# Altium schematic sheet COMPONENT BLOCKS 2.SchDoc
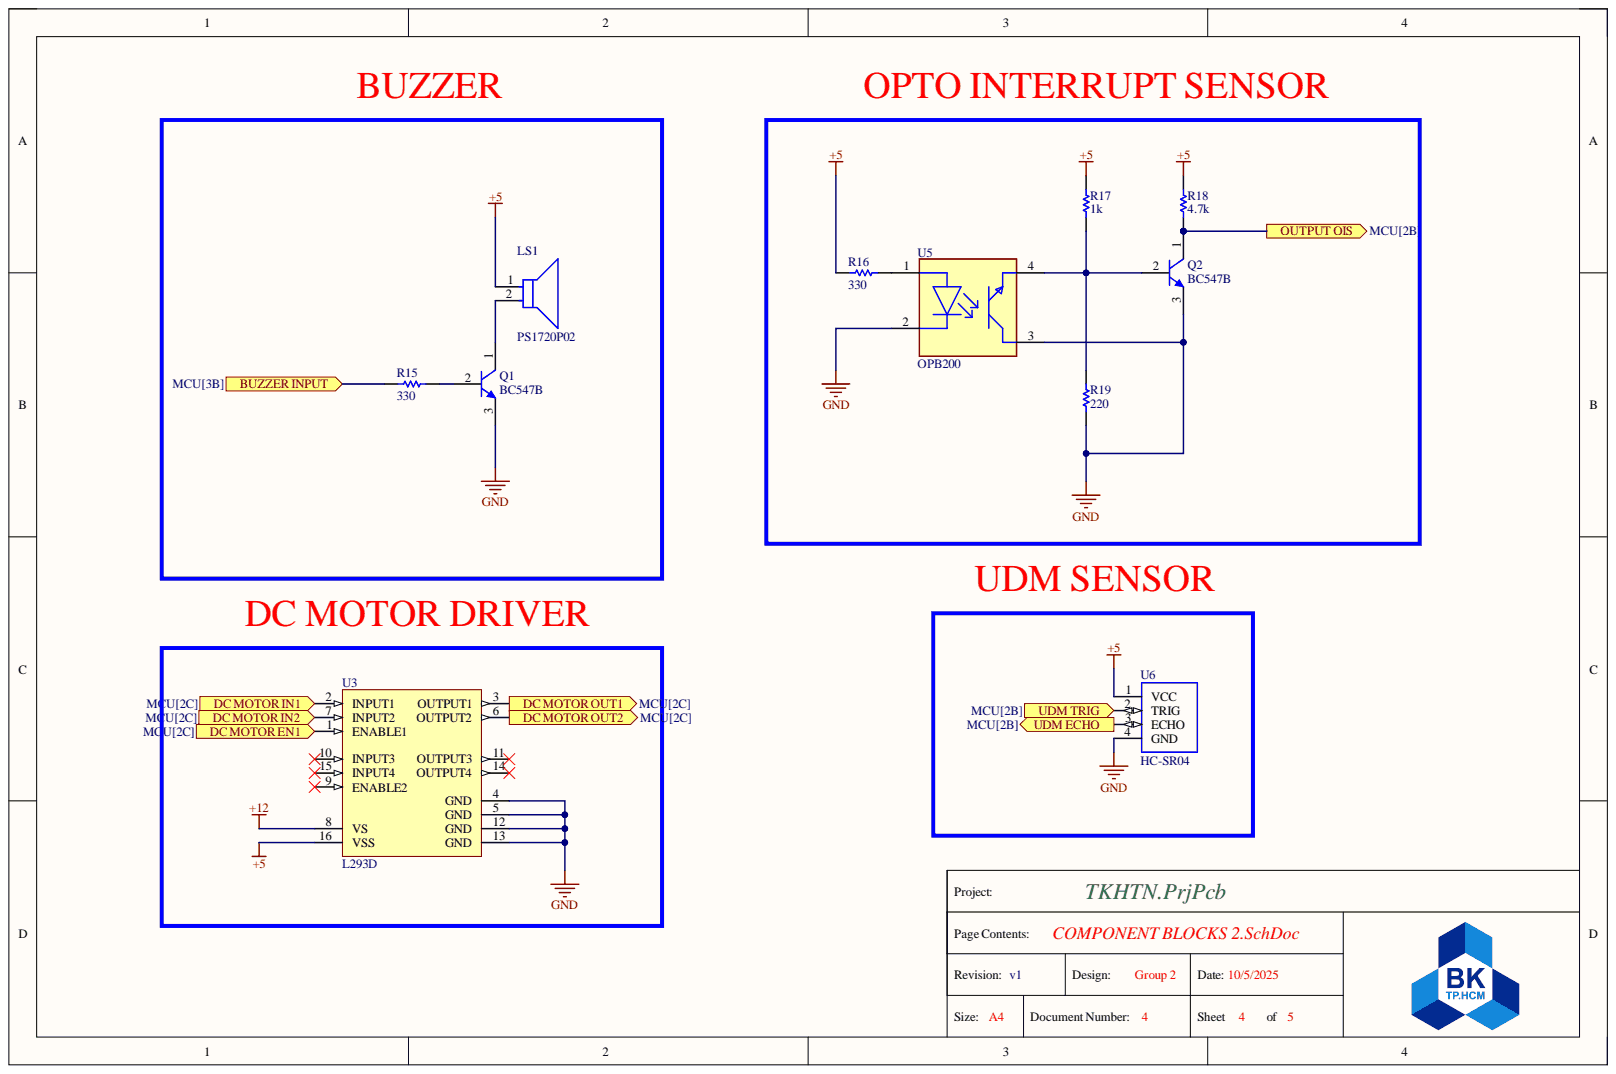
[diagram p0: COMPONENT BLOCKS 2.SchDoc]

## Parts
LS1  PS1720P02  [FP-PS1720P02-MFG]
Q1  BC547B  [ONSC-TO-92-3-29-11]
Q2  BC547B  [ONSC-TO-92-3-29-11]
R15  value 330  (hidden comment: Resistor)
R16  value 330  (hidden comment: Resistor)
R17  value 1k  (hidden comment: Resistor)
R18  value 4.7k  (hidden comment: Resistor)
R19  value 220  (hidden comment: Resistor)
U3  L293D  [STM-L293D-16]
U5  OPB200  [FP-OPB200-MFG]
U6  HC-SR04  [XCVR_HC-SR04]

## Ports
BUZZER INPUT, DC MOTOR EN1, DC MOTOR IN1, DC MOTOR IN2, DC MOTOR OUT1, DC MOTOR OUT2, OUTPUT OIS, UDM ECHO, UDM TRIG

## Nets
+5: LS1.1 R16.1 R17.2 R18.2 U3.16(VSS) U6.1(VCC)
+12: U3.8(VS)
GND: Q1.3 Q2.3 R19.1 U3.4(GND) U3.5(GND) U3.12(GND) U3.13(GND) U5.2 U5.3 U6.4(GND)
BUZZER INPUT: R15.1 ; port BUZZER INPUT
DC MOTOR EN1: U3.1(ENABLE1) ; port DC MOTOR EN1
DC MOTOR IN1: U3.2(INPUT1) ; port DC MOTOR IN1
DC MOTOR IN2: U3.7(INPUT2) ; port DC MOTOR IN2
DC MOTOR OUT1: U3.3(OUTPUT1) ; port DC MOTOR OUT1
DC MOTOR OUT2: U3.6(OUTPUT2) ; port DC MOTOR OUT2
OUTPUT OIS: Q2.1 R18.1 ; port OUTPUT OIS
UDM ECHO: U6.3(ECHO) ; port UDM ECHO
UDM TRIG: U6.2(TRIG) ; port UDM TRIG
NetLS1_2: LS1.2 Q1.1
NetQ1_2: Q1.2 R15.2
NetQ2_2: Q2.2 R17.1 R19.2 U5.4
NetR16_2: R16.2 U5.1

Not connected: U3 9(ENABLE2) 10(INPUT3) 11(OUTPUT3) 14(OUTPUT4) 15(INPUT4)

## Library data of the parts
LS1 = CMP-08413-000001-1: AUDIO PIEZO TRANSDUCER 30V TH; Manufacturer: TDK Corporation; Frequency: 2kHz; Standoff Height: 0.5mm; Automotive: No; Category: Speak; Component Kind: Standard; ComponentLink2Description: Datasheet; ComponentLink2URL: https://product.tdk.com/info/en/catalog/datasheets/piezoelectronic_buzzer_ps_en.pdf; Device Class L1: Electromechanical; Device Class L2: Audio Products; Device Class L3: Buzzers; Digikey Description: AUDIO PIEZO TRANSDUCER 30V TH; Height: 8.3mm; Lead Free: Yes; Library Name: piezo buzzer.IntLib; Manufacturer Part Number: PS1720P02; Mouser Description: Audio Indicators & Alerts Round 17mmx8mm 2 kHz Vin=3V; Octopart: https://octopart.com/ps1720p02-tdk-154429; Package: 0; RoHS: TRUE; Temperature: -10°C to +70°C; Temperature Range High: +70°C; Temperature Range Low: -10°C
Q1 Q2 = CMP-1048-01467-1: Amplifier Transistor, NPN Silicon, 3-Pin TO-92, Bulk Box; Manufacturer: On Semiconductor; fT Min (MHz): 150; IC Continuous (A): 0.1; Component Kind: Standard; ComponentLink1Description: Manufacturer URL; ComponentLink1URL: http://www.onsemi.com/; ComponentLink2Description: Datasheet; ComponentLink2URL: http://www.onsemi.com/pub_link/Collateral/BC546-D.PDF; ComponentLink3Description: Package Specification; ComponentLink3URL: http://www.onsemi.cn/pub_link/Collateral/2N6426-D.PDF; DatasheetVersion: Rev. 7; Library Name: npn bc547.IntLib; Mounting Technology: Through Hole; PackageDescription: 3-Pin Transistor Outline, Body 4.825 x 3.685 mm, Pitch 1.27 mm; PackageReference: TO-92-3-29-11_AM_SL; PackageVersion: Rev. AM; Packing: Bulk Box; PartNumber: BC547B; Polarity: NPN; RoHS: FALSE
R15-R19 = Resistor: SimulationGenericComponent: True; TC1: 0; Component Kind: Standard; Description: Resistor; Library Name: Simulation Generic Components.IntLib; Simulation: R_Resistor_Default; TC2: 0
U3 = CMP-2000-06524-1: Push-Pull Four Channel Driver with Diode, 4.5 to 36 V, -40 to 150 degC, 16-Pin PDIP, RoHS, Tube; Manufacturer: STMicroelectronics; Current - Output / Channel: 600mA; Current - Peak Output: 1.2A; Dynamic Catalog: HalfBridge; Input Type: Non-Inverting; Number of Outputs: 4; Other Names: 497-2936-5; Other Related Documents: L293DViewAllSpecifications; Voltage - Supply: 4.5V~36V; Category: IntegratedCircuits(ICs); Component Kind: Standard; Datasheet URL: http://www.st.com/st-web-ui/static/active/en/resource/technical/document/datasheet/CD00000059.pdf; Datasheet Version: 07/2003; Family: PMIC-MOSFET,BridgeDrivers-InternalSwitch; Library Name: DC Motor Driver.IntLib; Manufacturer URL: http://www.st.com/; Mounting Technology: ThroughHole; Mounting Type: ThroughHole; On-State Resistance: -; Operating Temperature: -40°C~150°C; Package / Case: 16-DIP(0.300",7.62mm); Package Description: 16-Pin Thru-Hole Dual-In-Line, Body 20 x 6.85 mm, Pitch 2.54 mm; Package Reference: DIP-16; Packaging: Tube; PartNumber: L293D; Series: -; Standard Package: 25; Supplier Device Package: 16-PowerDIP; Type: HalfBridge
U5 = CMP-70672-000001-1: SENSR OPTO SLOT 5.1MM TRANS THRU; Manufacturer: Optek; Collector Emitter Breakdown Voltage: 30V; Dark Current: 0.1uA; Led Orientation: Top View; Max Collector Current: 30mA; Power Dissipation: 100mW; Sensor Output: Other; Wavelength: 890nm; Automotive: No; Category: IC; Component Kind: Standard; ComponentLink2Description: Datasheet; ComponentLink2URL: https://www.ttelectronics.com/TTElectronics/media/ProductFiles/Optoelectronics/Datasheets/OPB200.pdf; Device Class L1: Sensors; Device Class L2: Optical Sensors; Device Class L3: Phototransistor; Digikey Description: SENSR OPTO SLOT 5.1MM TRANS THRU; Height: 10.41mm; Lead Free: Yes; Manufacturer Part Number: OPB200; Mouser Description: Optical Switches, Transmissive, Phototransistor Output Optical Switch; Octopart: https://octopart.com/opb200-optek-12408763; Package: OPB200; RoHS: TRUE; Temperature: -40°C to +85°C; Temperature Range High: +85°C; Temperature Range Low: -40°C; Library Name: Photoresist.IntLib
U6 = HC-SR04: Ultrasonic Sensor Module; Availability: Unavailable; Component Kind: Standard; Library Name: HC-SR04.IntLib; MP: HC-SR04; Package: None; Price: None
